# Altium schematic sheet power.SchDoc
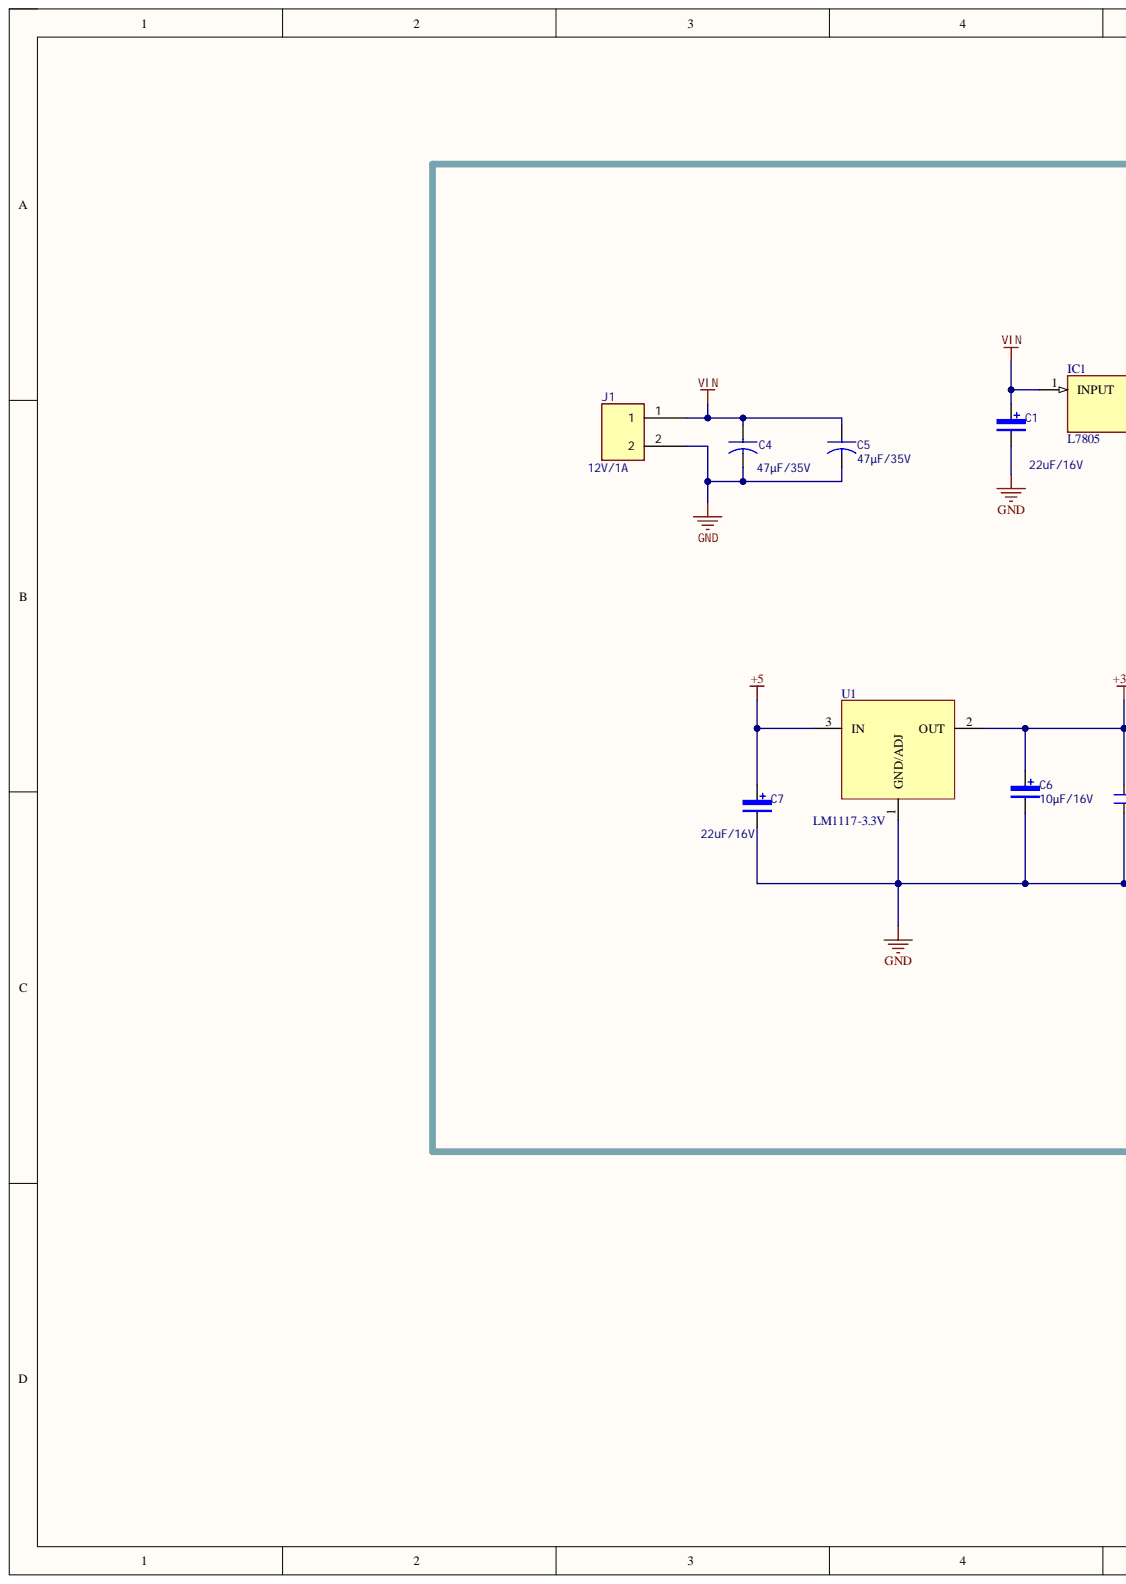
[diagram p0: power.SchDoc, left]
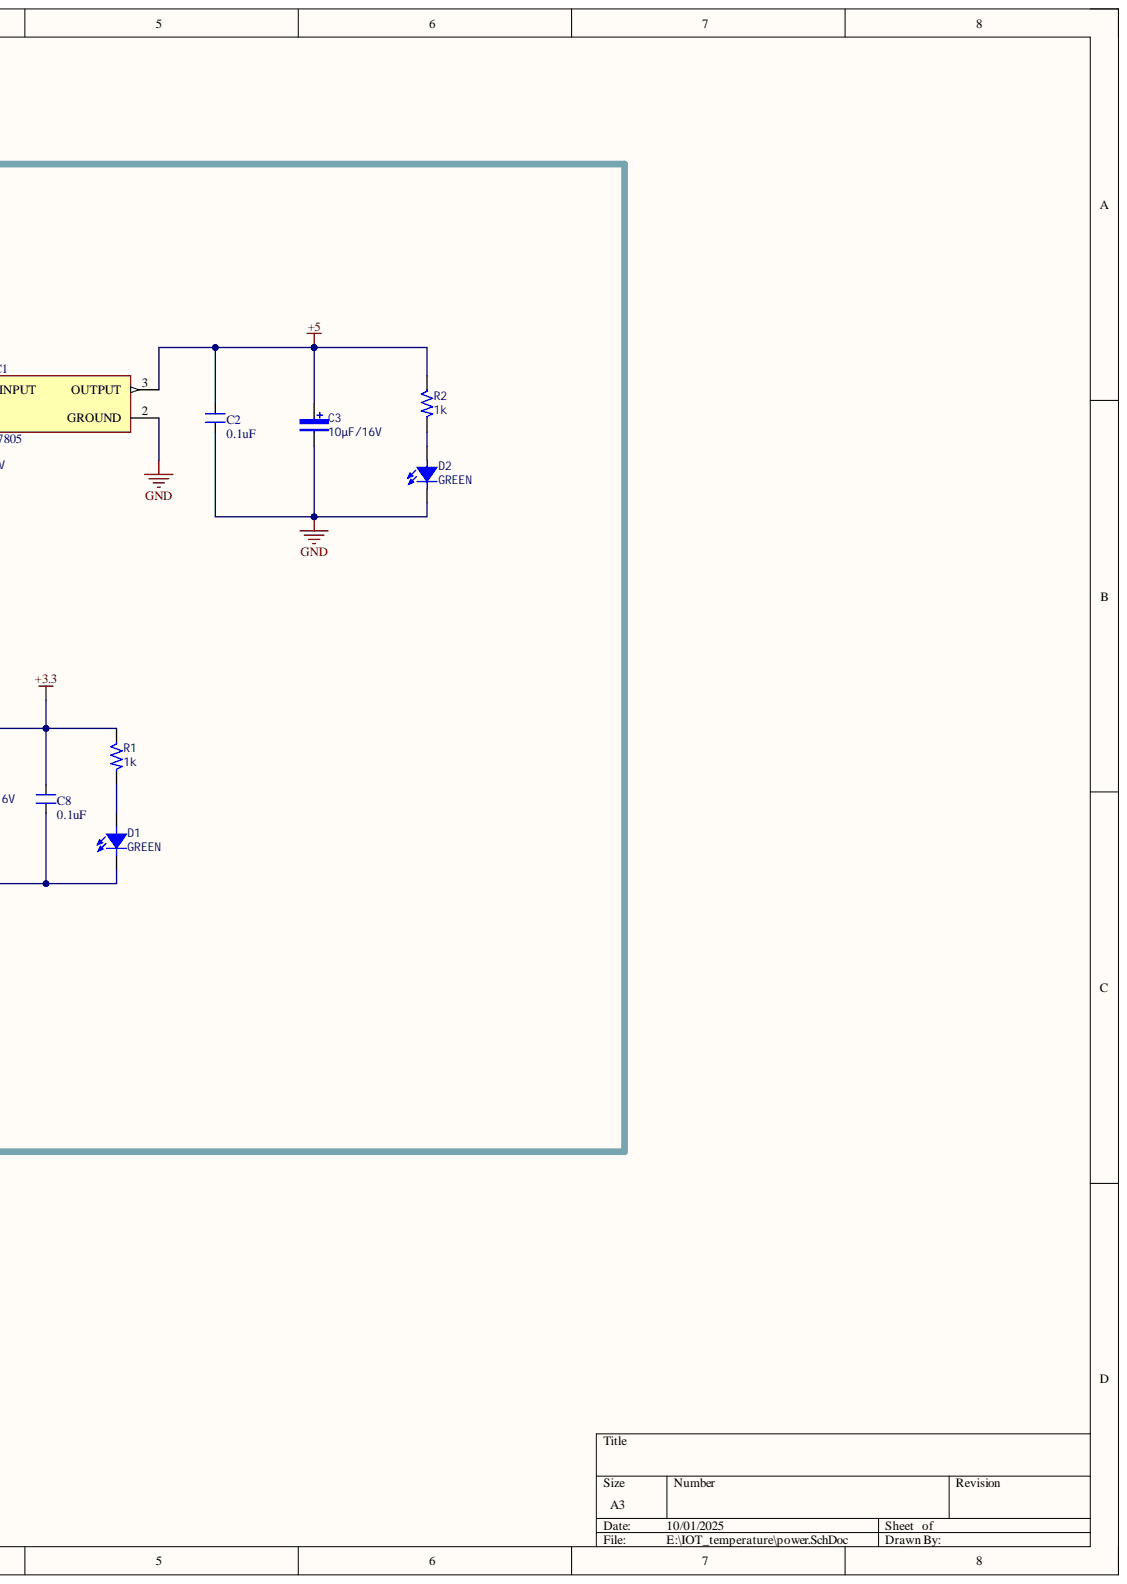
[diagram p1: power.SchDoc, right]

## Parts
C1  22uF/16V  [CAP TAN 2312]
C2  0.1uF  [CN2]
C3  10µF/16V  [CAP TAN 2312]
C4  47µF/35V  [EEH-ZA1V470P]
C5  47µF/35V  [EEH-ZA1V470P]
C6  10µF/16V  [CAP TAN 2312]
C7  22uF/16V  [CAP TAN 2312]
C8  0.1uF  [CN2]
D1  GREEN  [LED 0603]
D2  GREEN  [LED 0603]
IC1  L7805  [L7805]
J1  12V/1A  [VI0221520000G]
R1  1k  [RESISTOR_0603]
R2  1k  [RESISTOR_0603]
U1  LM1117-3.3V  [TO220-123]

## Nets
+3.3: C6.1 C8.2 R1.1 U1.2
+5: C2.2 C3.1 C7.1 IC1.3 R2.1 U1.3
GND: C1.2 C2.1 C3.2 C4.2 C5.2 C6.2 C7.2 C8.1 D1.2 D2.2 IC1.2 J1.2 U1.1
VIN: C1.1 C4.1 C5.1 IC1.1 J1.1
NetD1_1: D1.1 R1.2
NetD2_1: D2.1 R2.2

## Library data of the parts
C1 C7 = ID-3: Mfr# #: TAJC226K016RNJ; Database Table Name: Sheet1$; Component Kind: Standard; Design Item ID: ID-3; Library Name: Database.DbLib; Signal Integrity: Guessed Model; VALUE: 22uF/16V
C2 C8 = CN2: Cap np 104 => 105pF; Component Kind: Standard; Value: 0.1uF; Library Name: Compiled_Devzone.IntLib
C3 C6 = ID-2: Mfr# #: TAJC106K016RNJ; Database Table Name: Sheet1$; Component Kind: Standard; Design Item ID: ID-2; Library Name: Database.DbLib; Signal Integrity: Guessed Model; VALUE: 10µF/16V
C4 C5 = ID-4: Database Table Name: Sheet1$; Component Kind: Standard; Design Item ID: ID-4; Library Name: Database.DbLib; Mfr# #: EEH-ZA1V470P; Signal Integrity: Guessed Model; VALUE: 47µF/35V
D1 D2 = ID-23: Green 569nm LED Indication - Discrete 2.1V 0603 (1608 Metric); Mfr# #: LTST-C190GKT; Database Table Name: Sheet1$; Component Kind: Standard; Design Item ID: ID-23; Library Name: Database.DbLib; Signal Integrity: Guessed Model; Value: GREEN
J1 = ID-36: 2 Position Wire to Board Terminal Block Horizontal with Board 0.200" (5.08mm) Through Hole; Database Table Name: Sheet1$; Component Kind: Standard; Design Item ID: ID-36; Library Name: Database.DbLib; Mfr# #: VI0221520000G; Signal Integrity: Guessed Model
R1 R2 = RES-SMD: Component Kind: Standard; Library Name: ID.SchLib; Signal Integrity: Guessed Model
U1 = LM1117: Low-Dropout Linear Regulator; Component Kind: Standard; Website: http://group3i.net; Creator: Nguyen Ba Bien; Library Name: KhanhBK_Library_4.IntLib
IC1 = L7805: Component Kind: Standard; Library Name: Schlib1.SchLib
